# Altium schematic sheet Full-H Bridge_A.SchDoc("Full-H Bridge_A")
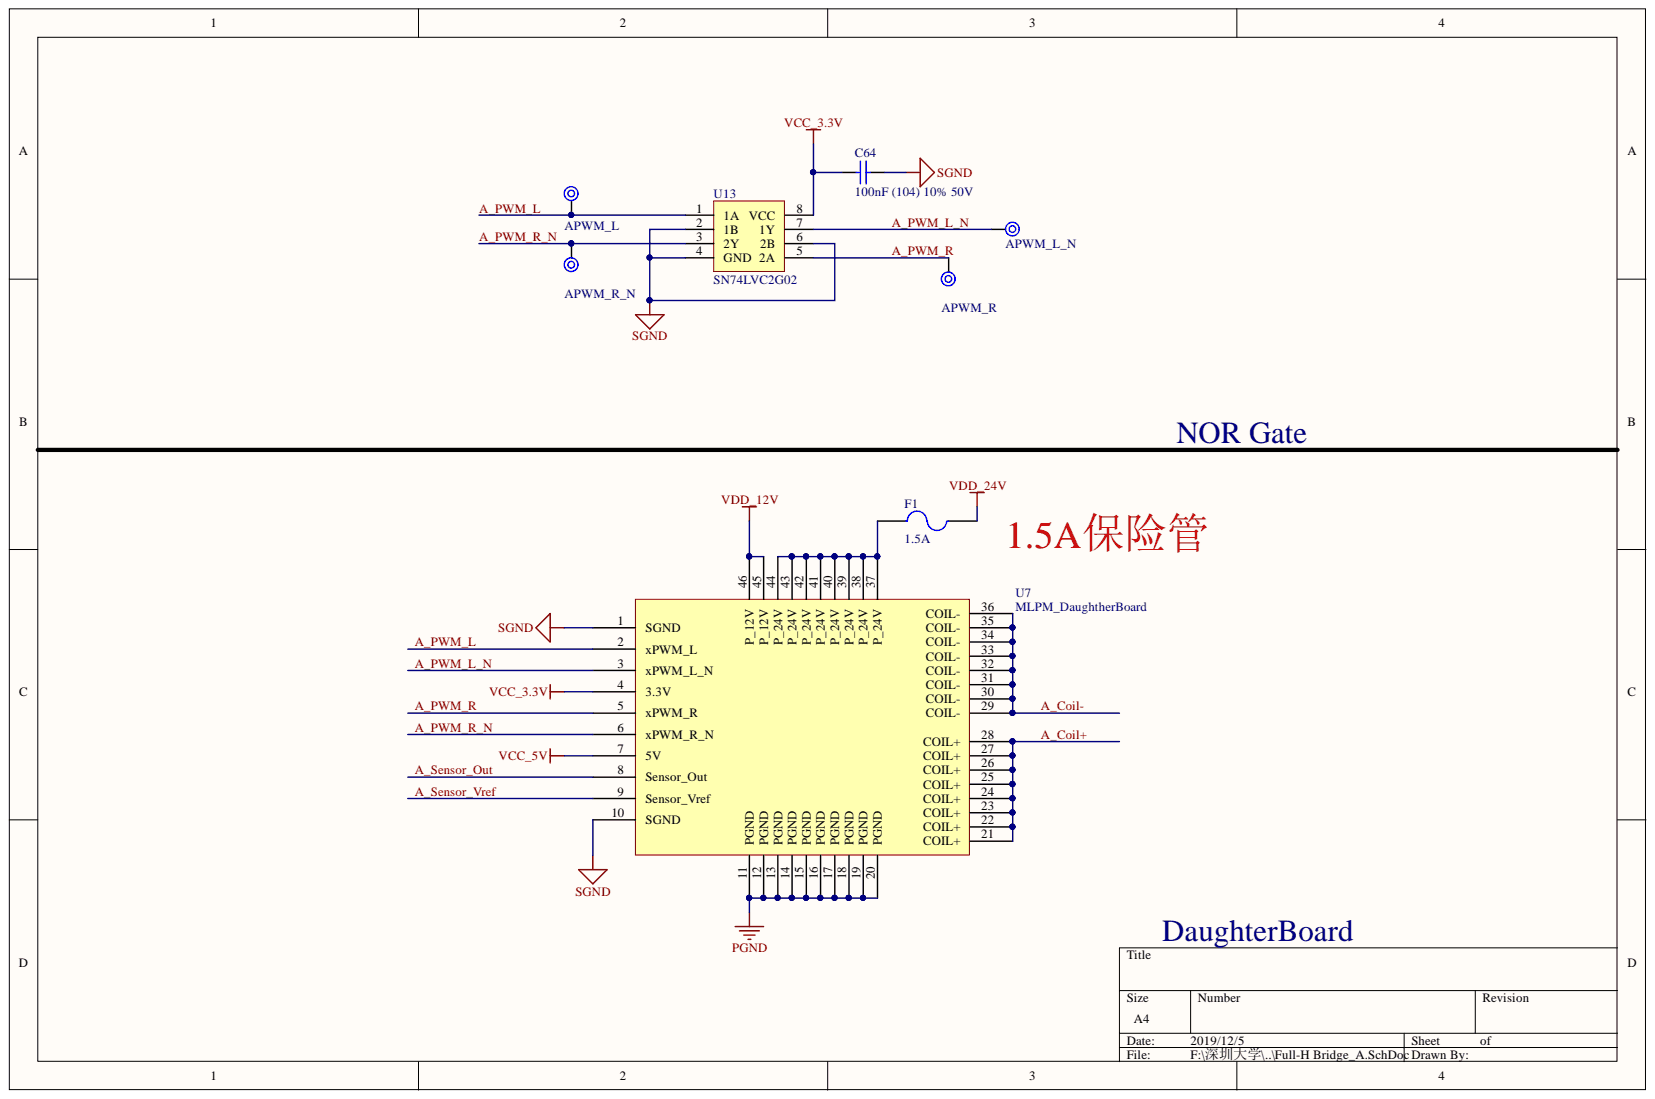
[diagram p0: Full-H Bridge_A.SchDoc]

## Parts
APWM_L  TP_Surface  [TEST_POINT_ME]  (value not drawn)
APWM_L_N  TP_Surface  [TEST_POINT_ME]  (value not drawn)
APWM_R  TP_Surface  [TEST_POINT_ME]  (value not drawn)
APWM_R_N  TP_Surface  [TEST_POINT_ME]  (value not drawn)
C64  100nF (104) 10% 50V  [0603_C]
F1  value 1.5A  [1812]  (hidden comment: Fuse)
U7  MLPM_DaughtherBoard  [MLPM_DaughtherBoard]
U13  SN74LVC2G02  [SN74LVC2G02]

## Nets
PGND: U7.11(PGND) U7.12(PGND) U7.13(PGND) U7.14(PGND) U7.15(PGND) U7.16(PGND) U7.17(PGND) U7.18(PGND) U7.19(PGND) U7.20(PGND)
SGND: C64.2 U7.1(SGND) U7.10(SGND) U13.2(1B) U13.4(GND) U13.6(2B)
VCC_3.3V: C64.1 U7.4(3.3V) U13.8(VCC)
VCC_5V: U7.7(5V)
VDD_12V: U7.45(P_12V) U7.46(P_12V)
VDD_24V: F1.2
A_Coil+: U7.21(COIL+) U7.22(COIL+) U7.23(COIL+) U7.24(COIL+) U7.25(COIL+) U7.26(COIL+) U7.27(COIL+) U7.28(COIL+)
A_Coil-: U7.29(COIL-) U7.30(COIL-) U7.31(COIL-) U7.32(COIL-) U7.33(COIL-) U7.34(COIL-) U7.35(COIL-) U7.36(COIL-)
A_PWM_L: APWM_L.1 U7.2(xPWM_L) U13.1(1A)
A_PWM_L_N: APWM_L_N.1 U7.3(xPWM_L_N) U13.7(1Y)
A_PWM_R: APWM_R.1 U7.5(xPWM_R) U13.5(2A)
A_PWM_R_N: APWM_R_N.1 U7.6(xPWM_R_N) U13.3(2Y)
A_Sensor_Out: U7.8(Sensor_Out)
A_Sensor_Vref: U7.9(Sensor_Vref)
NetF1_1: F1.1 U7.37(P_24V) U7.38(P_24V) U7.39(P_24V) U7.40(P_24V) U7.41(P_24V) U7.42(P_24V) U7.43(P_24V) U7.44(P_24V)

## Library data of the parts
C64 = 0603 100nF (104) 10% 50V: 贴片电容; SuppliersPartNumber: C14663; Supplier: LC; Package: 0603; Library Name: JLC Library.IntLib; ComponentLink1URL: http://www.szlcsc.com/product/details_15331.html; ComponentLink1Description: 供应商链接; Component Kind: Standard
F1 = Fuse 2: 保险丝; Published: 8-Jun-2000; PackageReference: PIN-W2/E2.8; LatestRevisionNote: Re-released for DXP Platform.; LatestRevisionDate: 17-Jul-2002; Component Kind: Standard; Publisher: Altium Limited; Library Name: 接插件集成库.IntLib
U7 = MLPM_Driver_DaugtherBoard: 磁悬浮平面电机电流驱动器子板; Component Kind: Standard; Library Name: MPLM_Driver_V10A1.IntLib
U13 = SN74LVC2G02: 2通道高速与非门; Component Kind: Standard; Library Name: PSRM_Sensorless_V2.0模块.IntLib
APWM_L APWM_L_N APWM_R APWM_R_N = TP_Surface: Component Kind: Standard; Library Name: PSRM_Sensorless_V2.0模块.IntLib
